# Altium schematic sheet Analiser.SchDoc(Analiser)
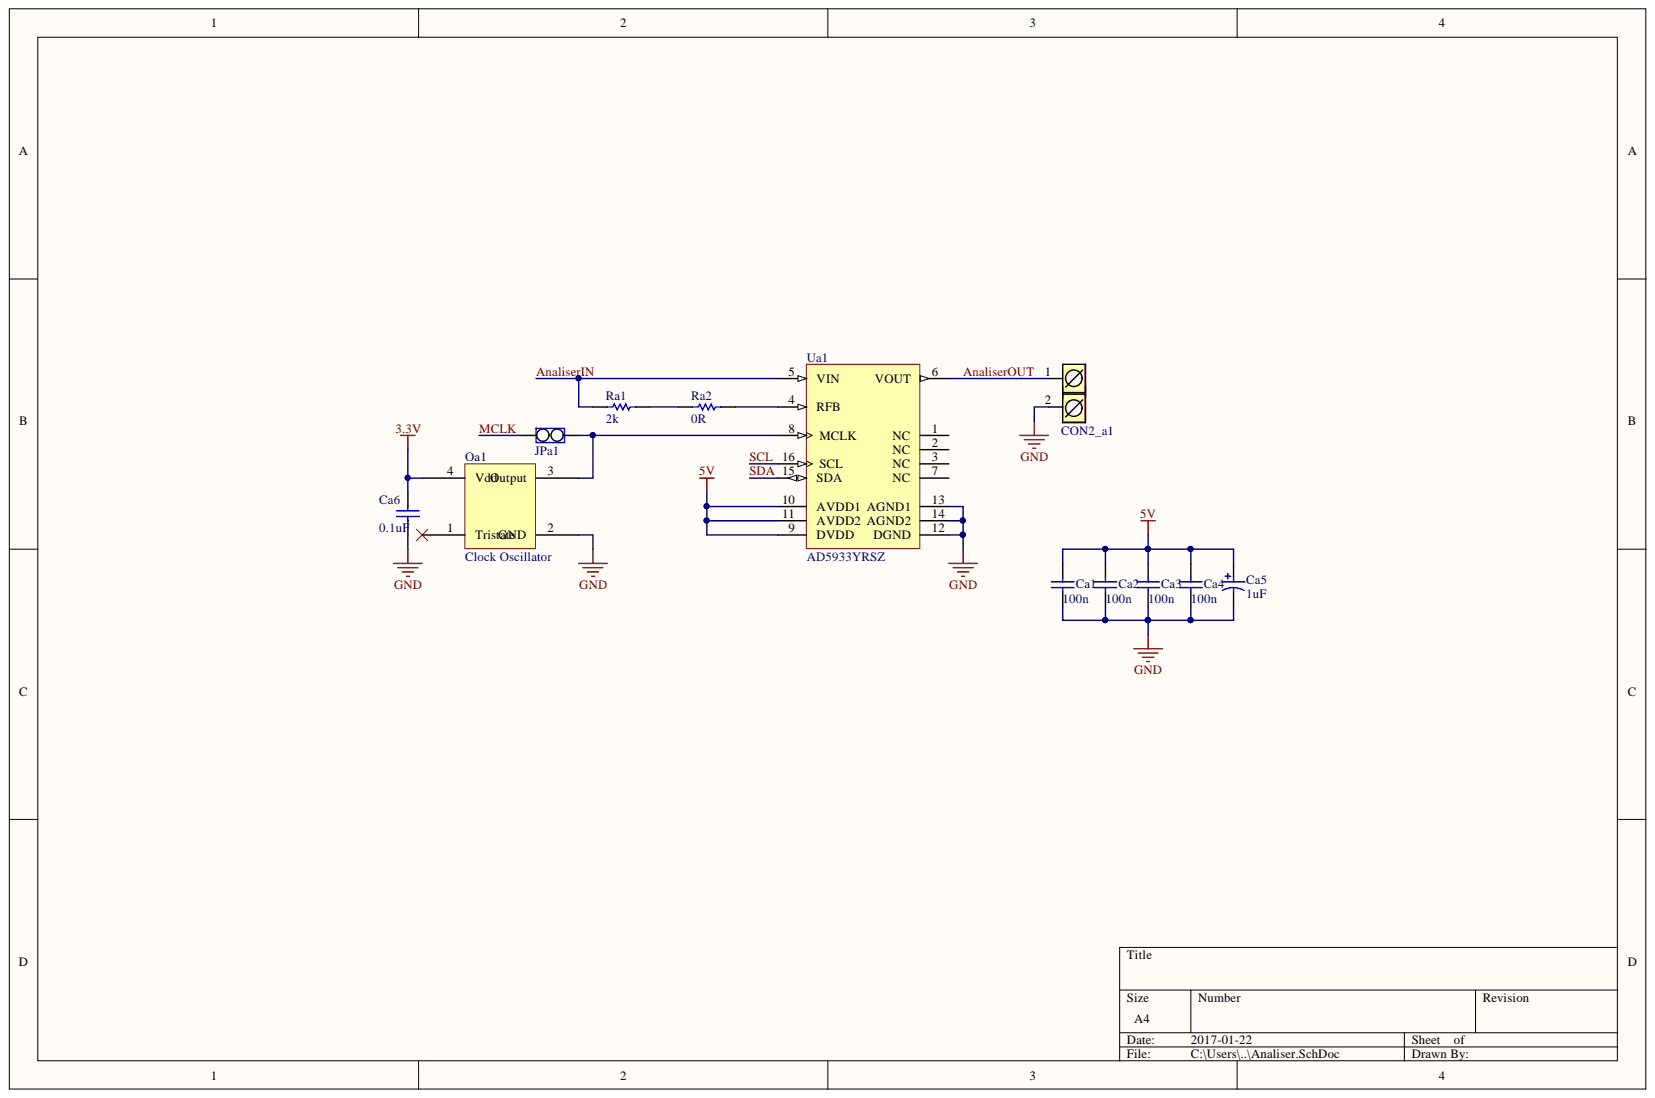
[diagram p0: Analiser.SchDoc]

## Parts
Ca1  100n  [CAPC0603(1608)100_L]
Ca2  100n  [CAPC0603(1608)100_L]
Ca3  100n  [CAPC0603(1608)100_L]
Ca4  100n  [CAPC0603(1608)100_L]
Ca5  1uF  [CAPMP3217X18-T_V]
Ca6  0.1uF  [CAPC0603(1608)100_L]
CON2_a1  ARK2  [ARK2]  (value not drawn)
JPa1  Jumper  [HDR1X2 - JP]  (value not drawn)
Oa1  Clock Oscillator  [Oscillator SMD]
Ra1  2k  [RESC0603(1608)_L]
Ra2  0R  [RESC0603(1608)_L]
Ua1  AD5933YRSZ  [ADI-RS-16_L]

## Nets
3.3V: Ca6.2 Oa1.4(Vdd)
5V: Ca1.2 Ca2.2 Ca3.2 Ca4.2 Ca5.1 Ua1.9(DVDD) Ua1.10(AVDD1) Ua1.11(AVDD2)
GND: Ca1.1 Ca2.1 Ca3.1 Ca4.1 Ca5.2 Ca6.1 CON2_a1.2 Oa1.2(GND) Ua1.12(DGND) Ua1.13(AGND1) Ua1.14(AGND2)
AnaliserIN: Ra1.1 Ua1.5(VIN)
AnaliserOUT: CON2_a1.1 Ua1.6(VOUT)
MCLK: JPa1.1
SCL: Ua1.16(SCL)
SDA: Ua1.15(SDA)
NetJPa1_2: JPa1.2 Oa1.3(Output) Ua1.8(MCLK)
NetRa1_2: Ra1.2 Ra2.1
NetRa2_2: Ra2.2 Ua1.4(RFB)

Not connected: Oa1 1(Tristate); Ua1 1(NC) 2(NC) 3(NC) 7(NC)

## Library data of the parts
Ca1-Ca4 Ca6 = CMP-1590-00005-1: 0603 (1608 Metric) Chip Capacitor; Case-Metric: 1608; Case-EIA: 0603; PackageReference: CAPC0603(1608)100; Library Name: Altium Content Vault; Component Kind: Standard
Ca5 = CMP-1661-00003-1: Standard Tantalum, 1 uF, +/- 10%, 35 V, -55 to 125 degC, 2-Pin SMD (1206), RoHS, Tape and Reel; Voltage Rating (DC): 30 V; Current Rating: 200 mA; Width: 4 mm; RoHSCompliant: true; REACH SVHC: No SVHC; Pins: 3; Packaging: Bulk; PackageReference: CAPMP3217X18-T; Package Quantity: 1000; PackageDescription: 2-Pin Surface Mount Device, Body 3.2 x 1.65 mm; Mount: Through Hole; Min Operating Temperature: -20 degC; Max Operating Temperature: 70 degC; Library Name: Altium Content Vault; Length: 11.6 mm; Height: 7.4 mm; DatasheetVersion: Feb-16; Contact Plating: Silver; Contact Material: Copper; Contact Finish: Silver; ComponentLink3URL: http://www.avx.com/download/software/literature/AVX-Footprint-Library.pdf; ComponentLink3Description: Package Specification; ComponentLink2URL: http://datasheets.avx.com/TAJ.pdf; ComponentLink2Description: Datasheet; ComponentLink1URL: http://www.avx.com/; ComponentLink1Description: Manufacturer URL; Component Kind: Standard
CON2_a1 = ARK2: Złącze śrubowe 2 piny; Library Name: Library_Altium.IntLib; Component Kind: Standard
JPa1 = Jumper_2: Jumper, 2-Pin; Library Name: Library_Altium.IntLib; Component Kind: Standard
Oa1 = Clock Oscillator: 1.8MHz Clock Source SMD; Component Kind: Standard; Library Name: Own.SchLib
Ra1 Ra2 = CMP-1591-00001-1: 0603 (1608 Metric) Chip Resistor; Case-Metric: 1608; Case-EIA: 0603; PackageReference: RESC0603(1608); Library Name: Altium Content Vault; Component Kind: Standard
Ua1 = CMP-0293-00046-1: 1 MSPS, 12-Bit Impedance Converter, Network Analyzer, Serial, RS-16, 77 Tube, Pb-Free; Tuning Word Width (bits): 27bit; Resolution (Bits): 12bit; Master fclk: 16.776MHz; I Supply total (max): 15mA; PackageVersion: 3/17/2005; PackageReference: RS-16; PackageDescription: 16-Lead Shrink Small Outline Package [SSOP]; Library Name: Altium Content Vault; I/O Interface: Serial; DatasheetVersion: Rev. D; ComponentLink3URL: http://www.analog.com/static/imported-files/packages/31572257652246RS_16.pdf; ComponentLink3Description: Package Specification; ComponentLink2URL: http://www.analog.com/static/imported-files/data_sheets/AD5933.pdf; ComponentLink2Description: Datasheet; ComponentLink1URL: www.analog.com; ComponentLink1Description: Manufacturer URL; Component Kind: Standard; Code_JEDEC: MO-150-AC
